# Altium schematic sheet Extension.SchDoc(Extension)
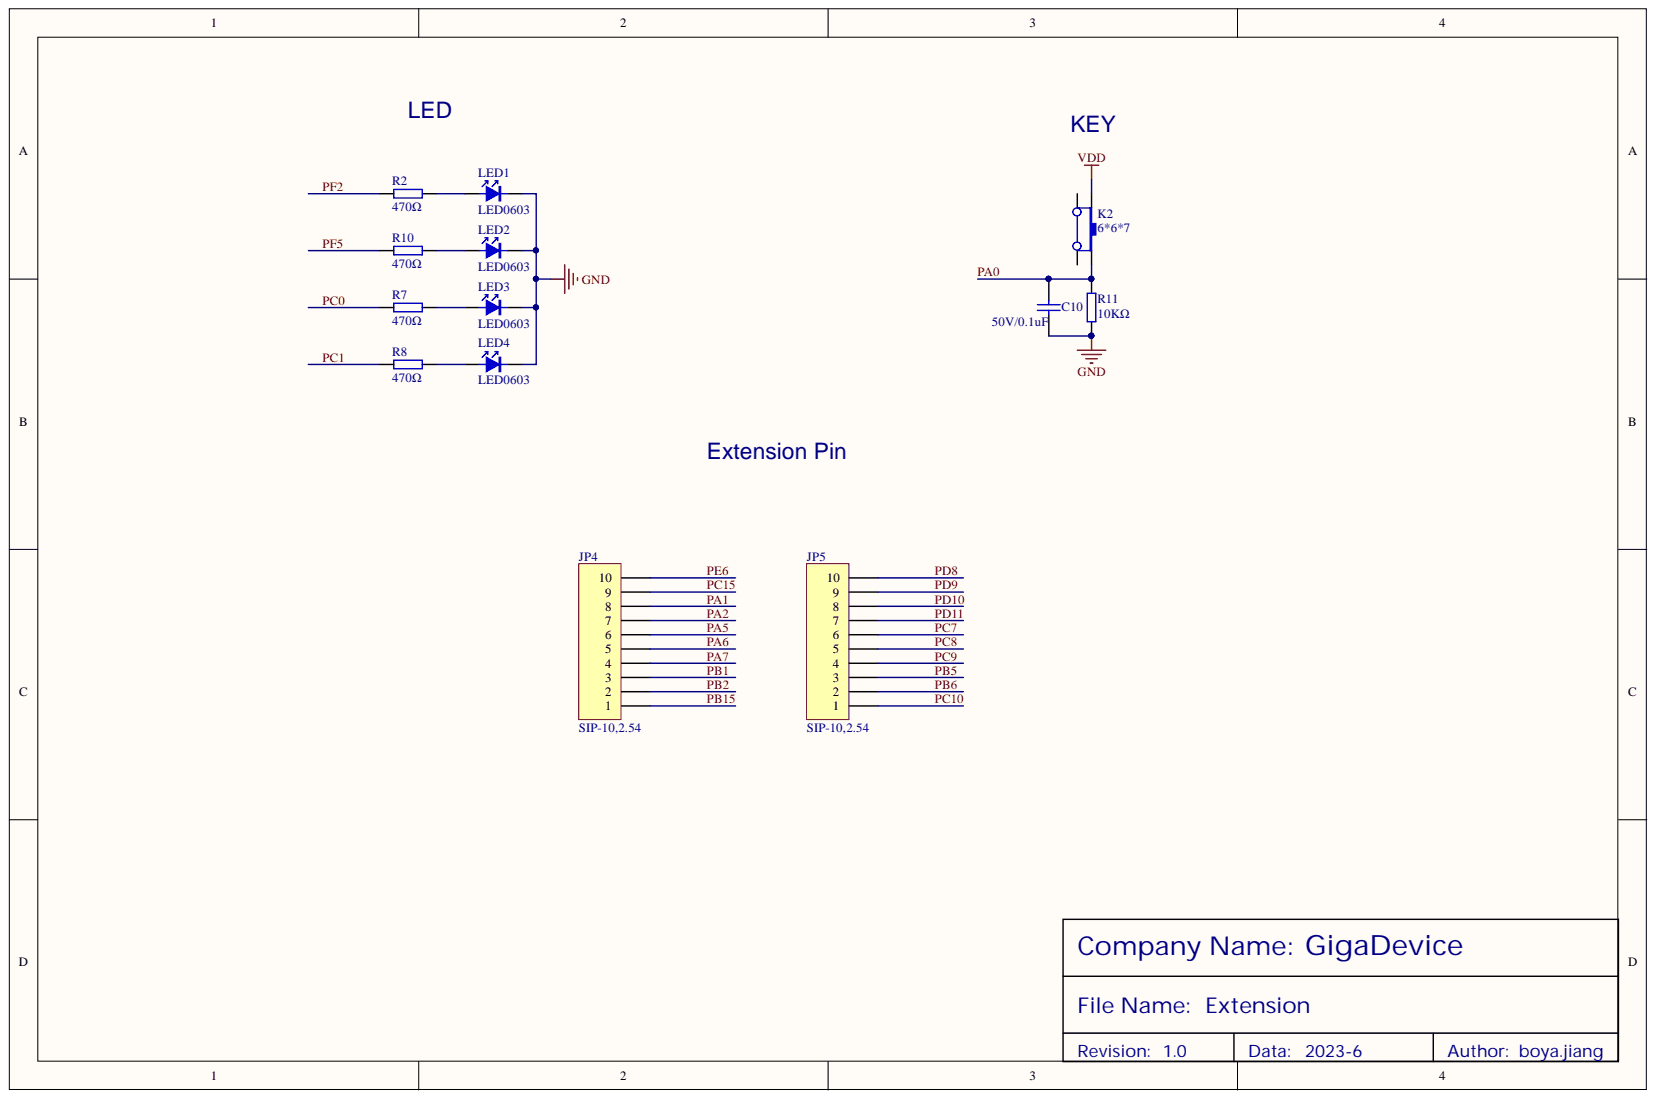
[diagram p0: Extension.SchDoc]

## Parts
C10  50V/0.1uF  [0603-C]
JP4  SIP-10,2.54  [SIP-10]
JP5  SIP-10,2.54  [SIP-10]
K2  6*6*7  [K-1102B]
LED1  LED0603  [0603-LED-Green]
LED2  LED0603  [0603-LED-Green]
LED3  LED0603  [0603-LED-Green]
LED4  LED0603  [0603-LED-Green]
R2  470Ω  [0603-R]
R7  470Ω  [0603-R]
R8  470Ω  [0603-R]
R10  470Ω  [0603-R]
R11  10KΩ  [0603-R]

## Nets
GND: C10.1 LED1.K LED2.K LED3.K LED4.K R11.2
VDD: K2.3
PA0: C10.2 K2.1 R11.1
PA1: JP4.8
PA2: JP4.7
PA5: JP4.6
PA6: JP4.5
PA7: JP4.4
PB1: JP4.3
PB2: JP4.2
PB5: JP5.3
PB6: JP5.2
PB15: JP4.1
PC0: R7.1
PC1: R8.1
PC7: JP5.6
PC8: JP5.5
PC9: JP5.4
PC10: JP5.1
PC15: JP4.9
PD8: JP5.10
PD9: JP5.9
PD10: JP5.8
PD11: JP5.7
PE6: JP4.10
PF2: R2.1
PF5: R10.1
NetLED1_A: LED1.A R2.2
NetLED2_A: LED2.A R10.2
NetLED3_A: LED3.A R7.2
NetLED4_A: LED4.A R8.2

Not connected: K2 2 4

## Library data of the parts
C10 = 50V/0.1uF: 表贴电容; Component Kind: Standard; Library Name: KLTC-电容.SchLib
JP4 JP5 = HEADER 10: 单排插针; Component Kind: Standard; Library Name: boyajiang.SchLib
K2 = K-1102B: 普通6X6X7按键; Component Kind: Standard; Library Name: KLTC-按键及接插件.SchLib
LED1-LED4 = LED0603: 表贴LED发光管; Component Kind: Standard; Library Name: xufei.SchLib
R2 R7 R8 R10 = 680Ω: 表贴电阻; Component Kind: Standard; Library Name: KLTC-电阻.SchLib
R11 = 10KΩ: 表贴电阻; Component Kind: Standard; Library Name: KLTC-电阻.SchLib
